# Altium schematic sheet Bluetooth_Modülü.SchDoc
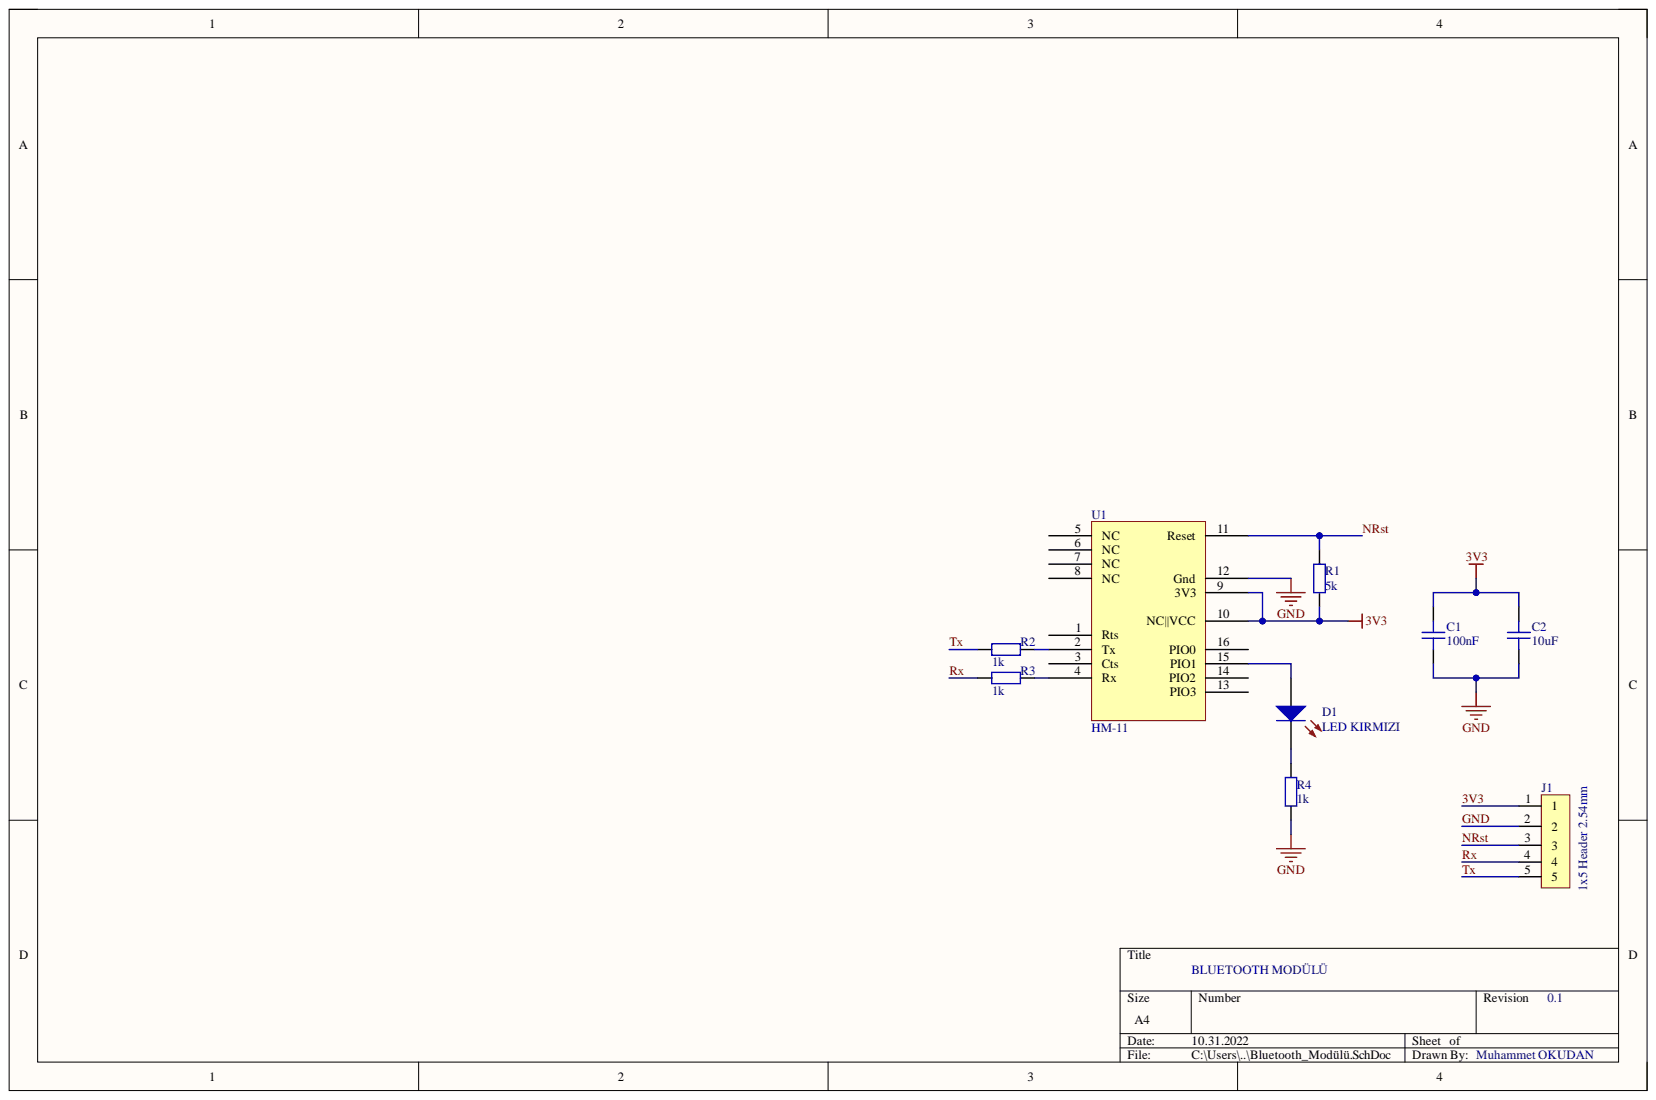
[diagram p0: Bluetooth_Modülü.SchDoc]

## Parts
C1  100nF  [0603 CAP]
C2  10uF  [0603 CAP]
D1  LED KIRMIZI  [LED KIRMIZI]
J1  1x5 Header 2.54mm  [1x5 Header 2.54mm]
R1  5k  [0603 RES]
R2  1k  [0603 RES]
R3  1k  [0603 RES]
R4  1k  [0603 RES]
U1  HM-11  [HM-11 Bluetooth Modüle]

## Nets
3V3: C1.2 C2.2 J1.1 R1.2 U1.9(3V3) U1.10(NC||VCC)
GND: C1.1 C2.1 J1.2 R4.2 U1.12(Gnd)
NRst: J1.3 R1.1 U1.11(Reset)
Rx: J1.4 R3.2
Tx: J1.5 R2.2
NetD1_1: D1.1 U1.15(PIO1)
NetD1_2: D1.2 R4.1
NetR2_1: R2.1 U1.2(Tx)
NetR3_1: R3.1 U1.4(Rx)

Not connected: U1 1(Rts) 3(Cts) 5(NC) 6(NC) 7(NC) 8(NC) 13(PIO3) 14(PIO2) 16(PIO0)

## Library data of the parts
C1 C2 = 0603 CAP: Component Kind: Standard; Library Name: Bluetooth_Modülü.SchLib
R1-R4 = RES 0603: Component Kind: Standard; Library Name: Bluetooth_Modülü.SchLib
U1 = HM-11: HM-11 Bluetooth Modülü; Component Kind: Standard; Library Name: Bluetooth_Modülü.SchLib
D1 = LED KIRMIZI: Component Kind: Standard; Library Name: Bluetooth_Modülü.SchLib
J1 = 1x5 Header 2.54mm: Component Kind: Standard; Library Name: Bluetooth_Modülü.SchLib
